# Altium schematic sheet Top.SchDoc
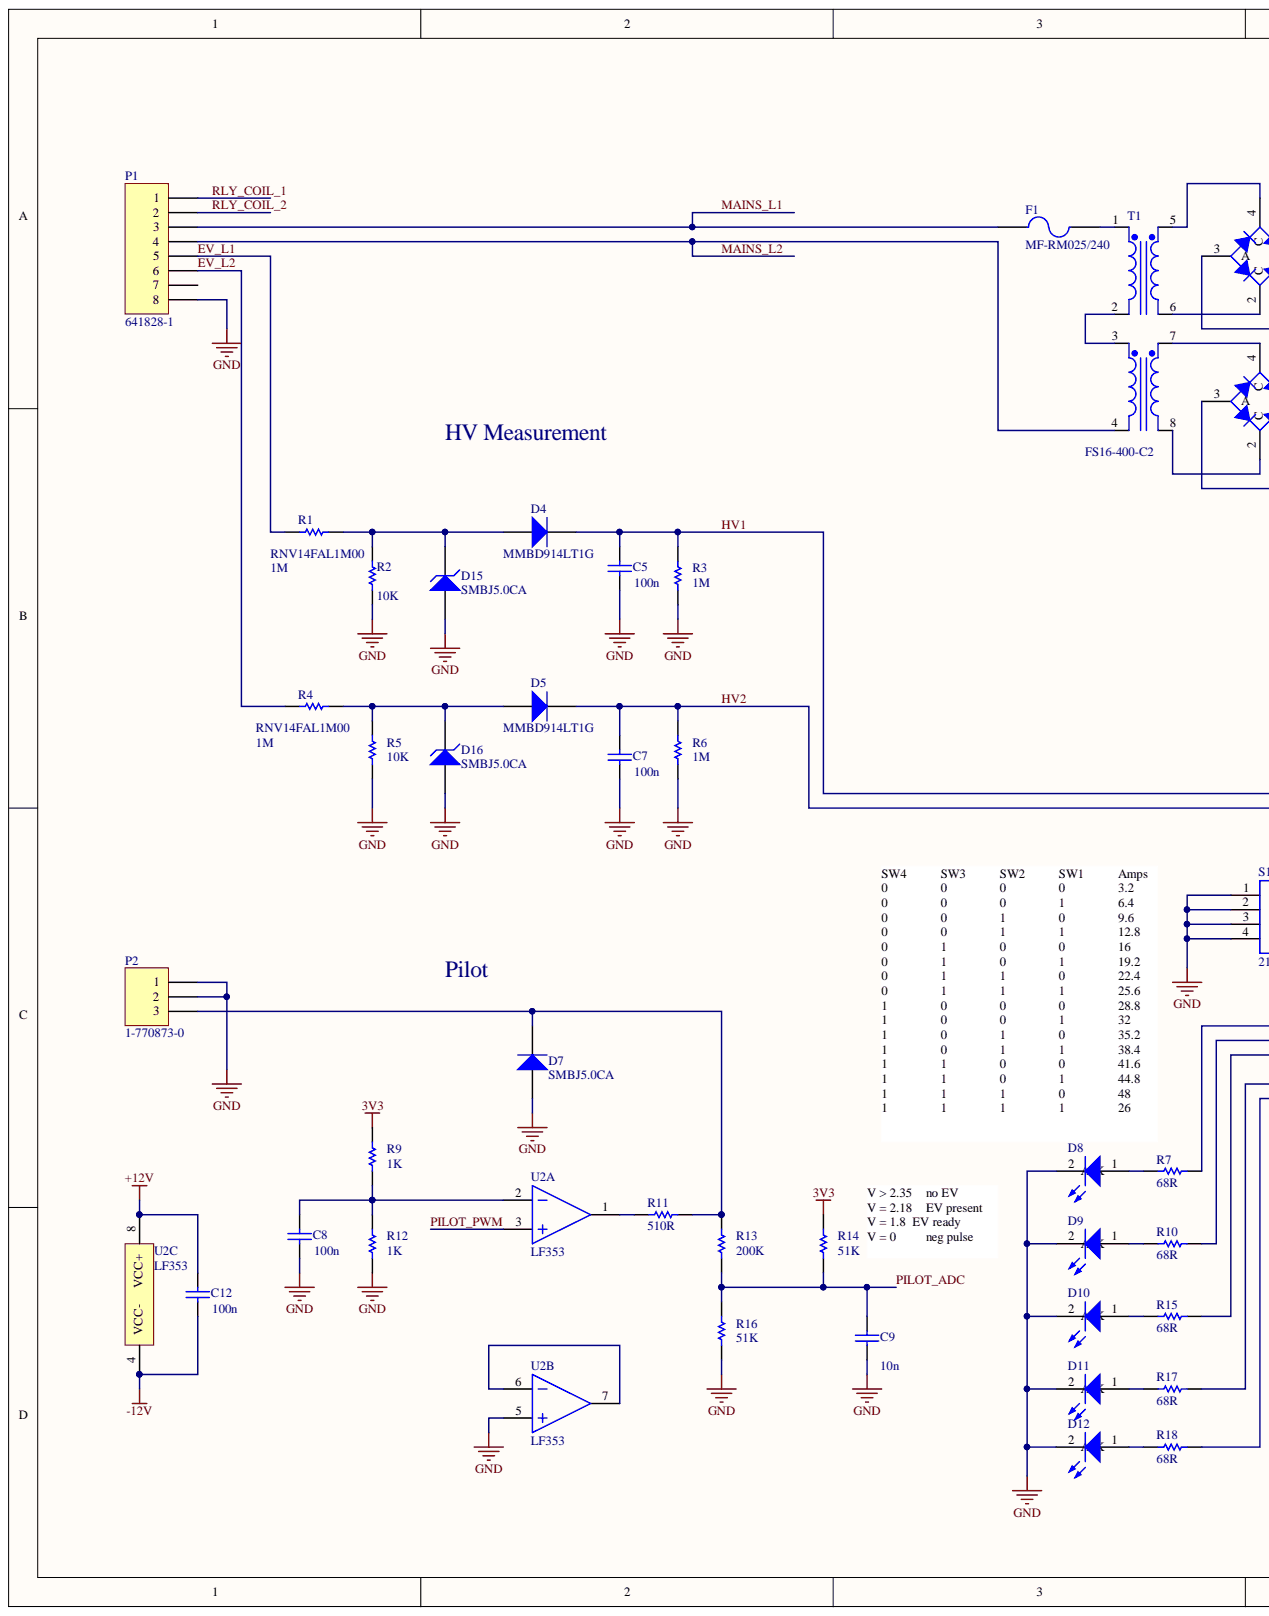
[diagram p0: Top.SchDoc, left]
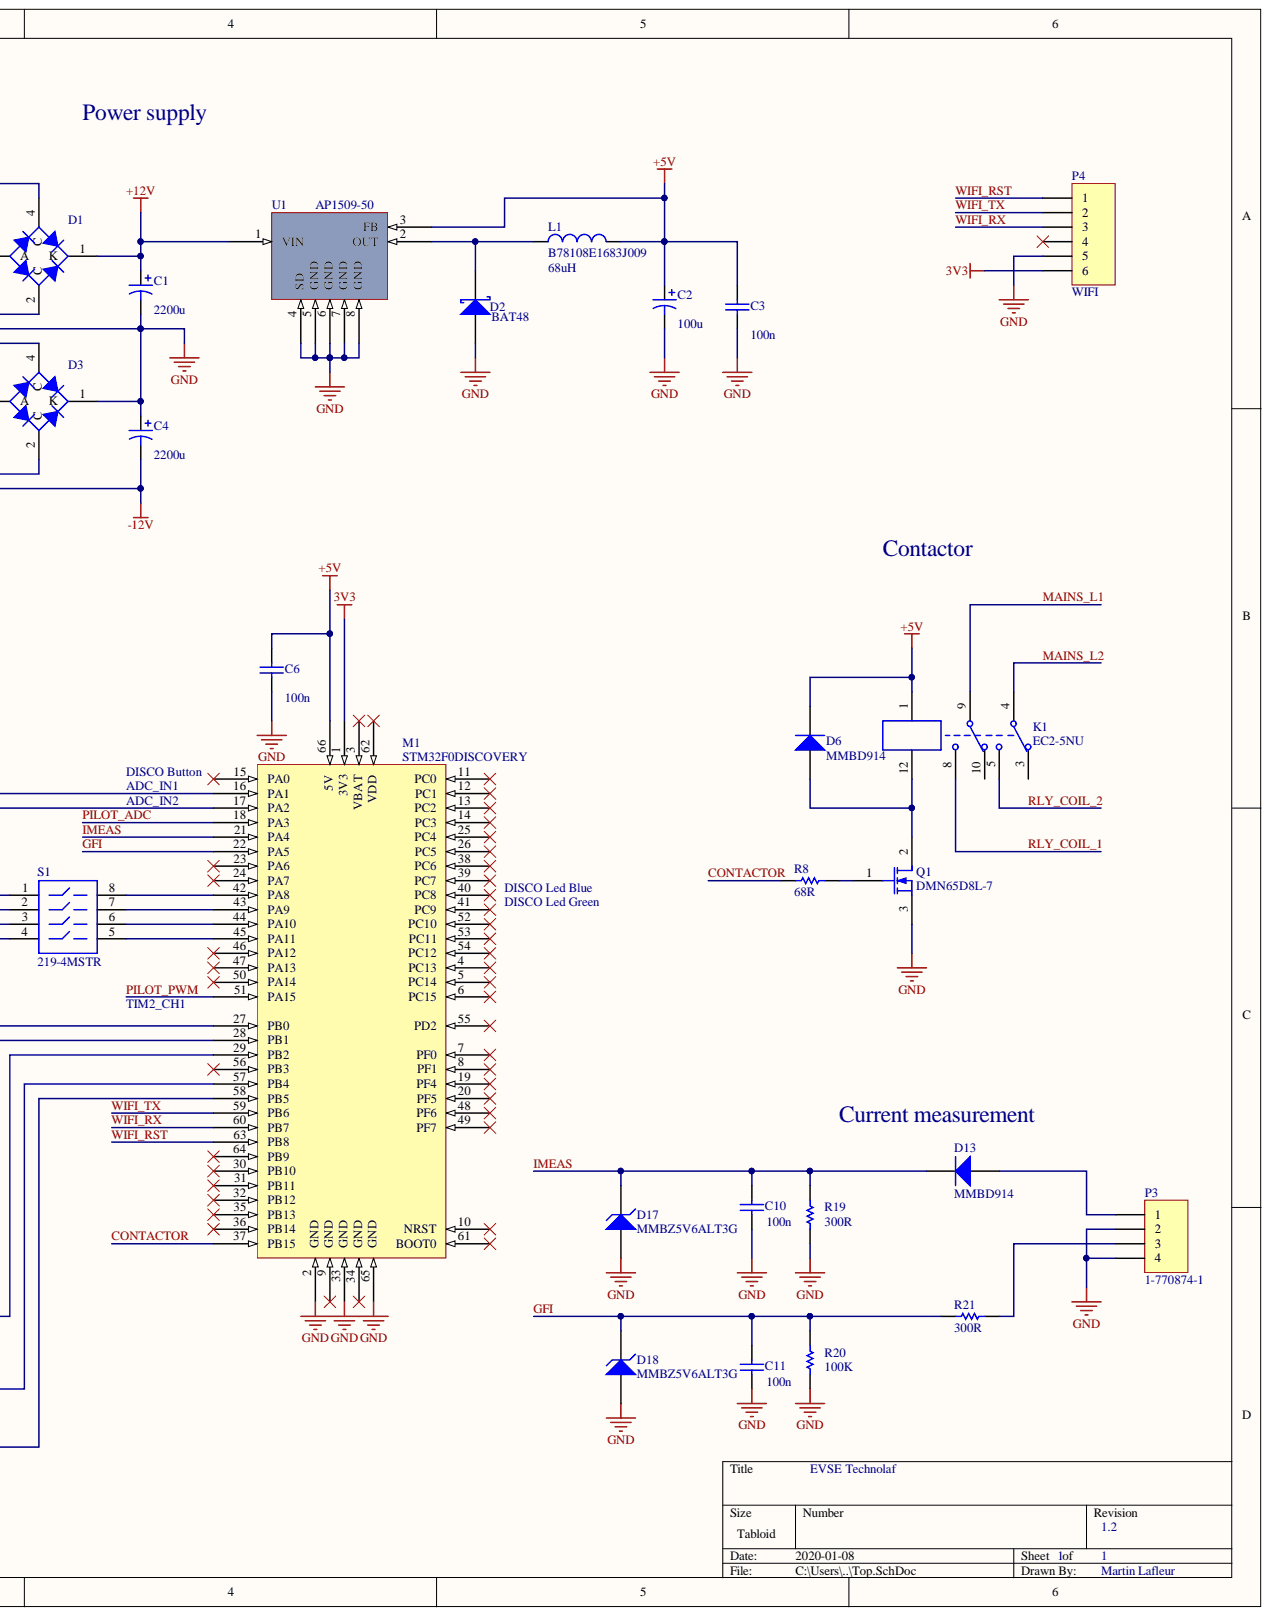
[diagram p1: Top.SchDoc, right]

## Parts
C1  value 2200u  [RB7.6-15]  (hidden comment: Cap Pol1)
C2  value 100u  [RB0.1]  (hidden comment: Cap Pol1)
C3  value 100n  [6-0805_M]  (hidden comment: Cap)
C4  2200u  [RB7.6-15]
C5  value 100n  [6-0805_M]  (hidden comment: Cap)
C6  value 100n  [6-0805_M]  (hidden comment: Cap)
C7  value 100n  [6-0805_M]  (hidden comment: Cap)
C8  value 100n  [6-0805_M]  (hidden comment: Cap)
C9  value 10n  [6-0805_M]  (hidden comment: Cap)
C10  value 100n  [6-0805_M]  (hidden comment: Cap)
C11  value 100n  [6-0805_M]  (hidden comment: Cap)
C12  value 100n  [6-0805_M]  (hidden comment: Cap)
D1  Bridge1  [KBP06M]  (value not drawn)
D2  BAT48  [AXIAL-0.6]
D3  Bridge1  [KBP06M]  (value not drawn)
D4  MMBD914LT1G  [SOT23_914]
D5  MMBD914LT1G  [SOT23_914]
D6  MMBD914  [SOT23_914]
D7  SMBJ5.0CA  [SMB]
D8  IN-S63AT5B  [LED_T13/4]
D9  (no value)  [LED_T13/4]
D10  (no value)  [LED_T13/4]
D11  (no value)  [LED_T13/4]
D12  (no value)  [LED_T13/4]
D13  MMBD914  [SOT23_914]
D15  SMBJ5.0CA  [SMB]
D16  SMBJ5.0CA  [SMB]
D17  MMBZ5V6ALT3G  [SOT23_914]
D18  MMBZ5V6ALT3G  [SOT23_914]
F1  MF-RM025/240  [MF-RM025/240]
K1  EC2-5NU  [EC2-5NU]
L1  B78108E1683J009  value 68uH  [AXIAL-0.6]
M1  STM32F0DISCOVERY  [STM32F0DISCO]
P1  641828-1  [641828-1]
P2  1-770873-0  [MATENLOK_1X3]
P3  1-770874-1  [MATENLOK_2X2]
P4  WIFI  [HDR1X6]
Q1  DMN65D8L-7  [SOT23_914]
R1  RNV14FAL1M00  value 1M  [AXIAL-0.6]
R2  value 10K  [6-0805_M]  (hidden comment: Res1)
R3  value 1M  [6-0805_M]  (hidden comment: Res1)
R4  RNV14FAL1M00  value 1M  [AXIAL-0.6]
R5  value 10K  [6-0805_M]  (hidden comment: Res1)
R6  value 1M  [6-0805_M]  (hidden comment: Res1)
R7  value 68R  [6-0805_M]  (hidden comment: Res1)
R8  value 68R  [6-0805_M]  (hidden comment: Res1)
R9  value 1K  [6-0805_M]  (hidden comment: Res1)
R10  value 68R  [6-0805_M]  (hidden comment: Res1)
R11  value 510R  [6-0805_M]  (hidden comment: Res1)
R12  value 1K  [6-0805_M]  (hidden comment: Res1)
R13  value 200K  [6-0805_M]  (hidden comment: Res1)
R14  value 51K  [6-0805_M]  (hidden comment: Res1)
R15  value 68R  [6-0805_M]  (hidden comment: Res1)
R16  value 51K  [6-0805_M]  (hidden comment: Res1)
R17  value 68R  [6-0805_M]  (hidden comment: Res1)
R18  value 68R  [6-0805_M]  (hidden comment: Res1)
R19  value 300R  [6-0805_M]  (hidden comment: Res1)
R20  value 100K  [6-0805_M]  (hidden comment: Res1)
R21  value 300R  [6-0805_M]  (hidden comment: Res1)
S1  219-4MSTR  [DIPSW4]
T1  FS16-400-C2  [FS16-400-C2]
U1  AP1509-50  [SO8_N]
U2  LF353  [SO8_N]  (parts A,B,C)

## Nets
3V3: M1.1(3V3) P4.6 R9.2 R14.2
+5V: C2.1 C3.2 C6.2 D6.2 K1.1 L1.2 M1.66(5V) U1.3(FB)
+12V: C1.1 C12.2 D1.1(K) U1.1(VIN) U2.8(VCC+)
-12V: C4.2 C12.1 D3.3(A) U2.4(VCC-)
GND: C1.2 C2.2 C3.1 C4.1 C5.1 C6.1 C7.1 C8.1 C9.1 C10.1 C11.1 D1.3(A) D2.1 D3.1(K) D7.1 D8.2 D9.2 D10.2 D11.2 D12.2 D15.1 D16.1 D17.1 D18.1 M1.2(GND) M1.33(GND) M1.65(GND) P1.8 P2.1 P2.2 P3.2 P3.4 P4.5 Q1.3 R2.1 R3.1 R5.1 R6.1 R12.1 R16.1 R19.1 R20.1 S1.1 S1.2 S1.3 S1.4 U1.4(SD) U1.5(GND) U1.6(GND) U1.7(GND) U1.8(GND) U2.5
CONTACTOR: M1.37(PB15) R8.2
EV_L1: P1.5 R1.2
EV_L2: P1.6 R4.2
GFI: C11.2 D18.2 M1.22(PA5) R20.2 R21.2
HV1: C5.2 D4.2 M1.16(PA1) R3.2
HV2: C7.2 D5.2 M1.17(PA2) R6.2
IMEAS: C10.2 D13.2 D17.2 M1.21(PA4) R19.2
MAINS_L1: F1.1 K1.9 P1.3
MAINS_L2: K1.4 P1.4 T1.4
PILOT_ADC: C9.2 M1.18(PA3) R13.1 R14.1 R16.2
PILOT_PWM: M1.51(PA15) U2.3
RLY_COIL_1: K1.8 P1.1
RLY_COIL_2: K1.5 P1.2
WIFI_RST: M1.63(PB8) P4.1
WIFI_RX: M1.60(PB7) P4.3
WIFI_TX: M1.59(PB6) P4.2
NetC8_2: C8.2 R9.1 R12.2 U2.2
NetD1_2: D1.2(C) T1.6
NetD1_4: D1.4(C) T1.5
NetD2_2: D2.2 L1.1 U1.2(OUT)
NetD3_2: D3.2(C) T1.8
NetD3_4: D3.4(C) T1.7
NetD4_1: D4.1 D15.2 R1.1 R2.2
NetD5_1: D5.1 D16.2 R4.1 R5.2
NetD6_1: D6.1 K1.12 Q1.2
NetD7_2: D7.2 P2.3 R11.1 R13.2
NetD8_1: D8.1 R7.2
NetD9_1: D9.1 R10.2
NetD10_1: D10.1 R15.2
NetD11_1: D11.1 R17.2
NetD12_1: D12.1 R18.2
NetD13_1: D13.1 P3.1
NetF1_2: F1.2 T1.1
NetM1_27: M1.27(PB0) R7.1
NetM1_28: M1.28(PB1) R10.1
NetM1_29: M1.29(PB2) R15.1
NetM1_42: M1.42(PA8) S1.8
NetM1_43: M1.43(PA9) S1.7
NetM1_44: M1.44(PA10) S1.6
NetM1_45: M1.45(PA11) S1.5
NetM1_57: M1.57(PB4) R17.1
NetM1_58: M1.58(PB5) R18.1
NetP3_3: P3.3 R21.1
NetQ1_1: Q1.1 R8.1
NetR11_2: R11.2 U2.1
NetT1_2: T1.2 T1.3
NetU2_6: U2.6 U2.7

Not connected: K1 3 10; M1 3(VBAT) 4(PC13) 5(PC14) 6(PC15) 7(PF0) 8(PF1) 9(GND) 10(NRST) 11(PC0) 12(PC1) 13(PC2) 14(PC3) 15(PA0) 19(PF4) 20(PF5) 23(PA6) 24(PA7) 25(PC4) 26(PC5) 30(PB10) 31(PB11) 32(PB12) 34(GND) 35(PB13) 36(PB14) 38(PC6) 39(PC7) 40(PC8) 41(PC9) 46(PA12) 47(PA13) 48(PF6) 49(PF7) 50(PA14) 52(PC10) 53(PC11) 54(PC12) 55(PD2) 56(PB3) 61(BOOT0) 62(VDD) 64(PB9); P1 7; P4 4

## Library data of the parts
C1 C2 C4 = Cap Pol1: Polarized Capacitor (Radial); Component Kind: Standard; LatestRevisionDate: 17-Jul-2002; LatestRevisionNote: Re-released for DXP Platform.; Library Name: Miscellaneous Devices.IntLib; PackageDescription: Polarized Capacitor (Radial); 2 Leads; PackageReference: RB7.6-15; Published: 8-Jun-2000; Signal Integrity: Cap Pol; Publisher: Altium Limited
  C2: Simulation: CAP
  C4: Simulation: CAP; Value: 2200u
C3 C5-C12 = Cap: Capacitor; Component Kind: Standard; LatestRevisionDate: 17-Jul-2002; LatestRevisionNote: Re-released for DXP Platform.; Library Name: Miscellaneous Devices.IntLib; PackageDescription: Radial Cap, Thru-Hole; 2 Leads; 0.3 in Pin Spacing; PackageReference: RAD-0.3; Published: 8-Jun-2000; Publisher: Altium Limited
  C5-C12: Signal Integrity: Cap; Simulation: CAP
D1 D3 = Bridge1: Full Wave Diode Bridge; Component Kind: Standard; DatasheetVersion: E2732B; LatestRevisionDate: 29-May-2009; LatestRevisionNote: Sch pins A & K swapped.; Library Name: Miscellaneous Devices.IntLib; PackageDescription: Bridge Rectifier; 4 Terminals; Body 9.4 x 9.4 mm (typ); Pitch 5.08 mm; PackageReference: D-38; Published: 8-Jun-2000; Publisher: Altium Limited
D2 = D Schottky: Schottky Diode; Code_JEDEC: DO-214-AA; Component Kind: Standard; LatestRevisionDate: 17-Jul-2002; LatestRevisionNote: Re-released for DXP Platform.; Library Name: Miscellaneous Devices.IntLib; PackageDescription: DO-214-AA/SMB; 2 C-Bend Leads; Body 5.3 x 3.6 mm, inc. leads (LxW); PackageReference: SMB; Published: 8-Jun-2000; Signal Integrity: D Schottky; Simulation: SKYDIODE; Publisher: Altium Limited
D4-D7 D13 = Diode: Default Diode; Code_JEDEC: DO-214-AB; Component Kind: Standard; LatestRevisionDate: 17-Jul-2002; LatestRevisionNote: Re-released for DXP Platform.; Library Name: Miscellaneous Devices.IntLib; PackageDescription: DO-214-AB/SMC; 2 C-Bend Leads; Body 7.9 x 5.9 mm, inc. leads (LxW); PackageReference: SMC; PackageVersion: Sep-1996; Published: 8-Jun-2000; Publisher: Altium Limited
  D4 D5 D13: Signal Integrity: Diode; Simulation: DIODE
D8-D12 = LED0: Typical INFRARED GaAs LED; Component Kind: Standard; LatestRevisionDate: 08-Jul-2005; LatestRevisionNote: Mech Layer 1 removed.; Library Name: Miscellaneous Devices.IntLib; PackageDescription: LED; 2 Leads; PackageReference: LED-0; Published: 8-Jun-2000; Publisher: Altium Limited
D15-D18 = D Zener: Zener Diode; Component Kind: Standard; LatestRevisionDate: 17-Jul-2002; LatestRevisionNote: Re-released for DXP Platform.; Library Name: Miscellaneous Devices.IntLib; PackageDescription: Diode, Thru-Hole; 2 Leads; 0.7 in Pin Spacing; PackageReference: DIODE-0.7; Published: 8-Jun-2000; Publisher: Altium Limited
  D17 D18: Signal Integrity: D Zener; Simulation: ZENER
F1 = Fuse 2: MF-RM025/240-2; Component Kind: Standard; LatestRevisionDate: 17-Jul-2002; LatestRevisionNote: Re-released for DXP Platform.; Library Name: Miscellaneous Devices.IntLib; PackageDescription: Thru-Hole; 2 Leads; PackageReference: PIN-W2/E2.8; Published: 8-Jun-2000; Publisher: Altium Limited
K1 = Relay-DPDT: Dual-Pole Dual-Throw Relay; Component Kind: Standard; LatestRevisionDate: 17-Jul-2002; LatestRevisionNote: Re-released for DXP Platform.; PackageDescription: Relay; 8 Leads; PackageReference: DIP-P8; Published: 24-Mar-1999; Library Name: laf.SchLib; Publisher: Altium Limited
L1 = Inductor: Code_IEC: 1005; Code_JEITA: 0402; Component Kind: Standard; Description: Inductor; LatestRevisionDate: 29-May-2009; LatestRevisionNote: IPC-7351 Footprint Added.; Library Name: Miscellaneous Devices.IntLib; PackageDescription: Chip Inductor; PackageReference: 0402-A; Published: 8-Jun-2000; Publisher: Altium Limited
P1 = Header 8: Header, 8-Pin; Component Kind: Standard; LatestRevisionDate: 17-Jul-2002; LatestRevisionNote: Re-released for DXP Platform.; Library Name: Miscellaneous Connectors.IntLib; Signal Integrity: Connector; Publisher: Altium Limited
P2 = Header 3: Header, 3-Pin; Component Kind: Standard; LatestRevisionDate: 17-Jul-2002; LatestRevisionNote: Re-released for DXP Platform.; Library Name: Miscellaneous Connectors.IntLib; Publisher: Altium Limited
P3 = Header 4: Header, 4-Pin; Component Kind: Standard; LatestRevisionDate: 17-Jul-2002; LatestRevisionNote: Re-released for DXP Platform.; Library Name: Miscellaneous Connectors.IntLib; Publisher: Altium Limited
P4 = Header 6: Header, 6-Pin; Component Kind: Standard; LatestRevisionDate: 17-Jul-2002; LatestRevisionNote: Re-released for DXP Platform.; Library Name: Miscellaneous Connectors.IntLib; Signal Integrity: Connector; Publisher: Altium Limited
Q1 = MOSFET-N: N-Channel MOSFET; Component Kind: Standard; LatestRevisionDate: 17-Jul-2002; LatestRevisionNote: Re-released for DXP Platform.; PackageDescription: TO, Flat Index; 3 In-Line, Axial Leads; Body Dia. 4.6mm; Lead Dia. 0.5 mm (max); PackageReference: E3; PackageVersion: 1995/1996; Published: 8-Jun-2000; Simulation: NMOS; Library Name: laf.SchLib; Publisher: Altium Limited
R1-R21 = Res1: Resistor; Component Kind: Standard; LatestRevisionDate: 17-Jul-2002; LatestRevisionNote: Re-released for DXP Platform.; Library Name: Miscellaneous Devices.IntLib; PackageDescription: Axial Device, Thru-Hole; 2 Leads; 0.3 in Pin Spacing; PackageReference: AXIAL-0.3; Published: 8-Jun-2000; Publisher: Altium Limited
  R1 R3-R21: Signal Integrity: Res; Simulation: RESISTOR
S1 = SW-DIP4: DIP Switch; Component Kind: Standard; LatestRevisionDate: 17-Jul-2002; LatestRevisionNote: Re-released for DXP Platform.; Library Name: Miscellaneous Devices.IntLib; PackageReference: DIP-8; Published: 24-Mar-1999; Simulation: dpsw4; Publisher: Altium Limited
T1 = TRANSFO_2p2s: Component Kind: Standard; Library Name: laf.SchLib
U1 = AP1509: Component Kind: Standard; Library Name: laf.SchLib
U2 = LM358P: IC OPAMP GP 700KHZ 8DIP; Manufacturer: Texas Instruments; Amplifier Type: General Purpose; Current - Input Bias: 20nA; Current - Output / Channel: 30mA; Current - Supply: 1mA; Emplacement: OP AMP TUBE; Number of Circuits: 2; Slew Rate: 0.3 V/µs; Voltage - Input Offset: 3mV; Voltage - Supply, Single/Dual (±): 3 V ~ 32 V, ±1.5 V ~ 16 V; -3db Bandwidth: -; Category: Integrated Circuits (ICs); Component Kind: Standard; ComponentLink1Description: http://www.ti.com/lit/ds/symlink/lm358.pdf; ComponentLink1URL: http://www.ti.com/lit/ds/symlink/lm358.pdf; Gain Bandwidth Product: 700kHz; Manufacturer Part Number: LM358P; Mounting Type: Through Hole; Operating Temperature: 0°C ~ 70°C; Output Type: -; Package / Case: 8-DIP (0.300", 7.62mm); Packaging: Tube; Pricing 1: 1=0.58, 10=0.45, 25=0.3788, 100=0.3085, 250=0.25568, 500=0.21156, 750=0.17631, 1000=0.15867 (CAD); RoHS: RoHS Compliant; Stock 1: 12094; Supplier 1: Digi-Key; Supplier Device Package: 8-PDIP; Supplier Part Number 1: 296-1395-5-ND; Library Name: GRR_IC.SchLib
M1 = STM32F0DISCOVERY: Component Kind: Standard; Library Name: laf.SchLib
